# Altium schematic sheet 04_gap_interface.SchDoc(04_gap_interface)
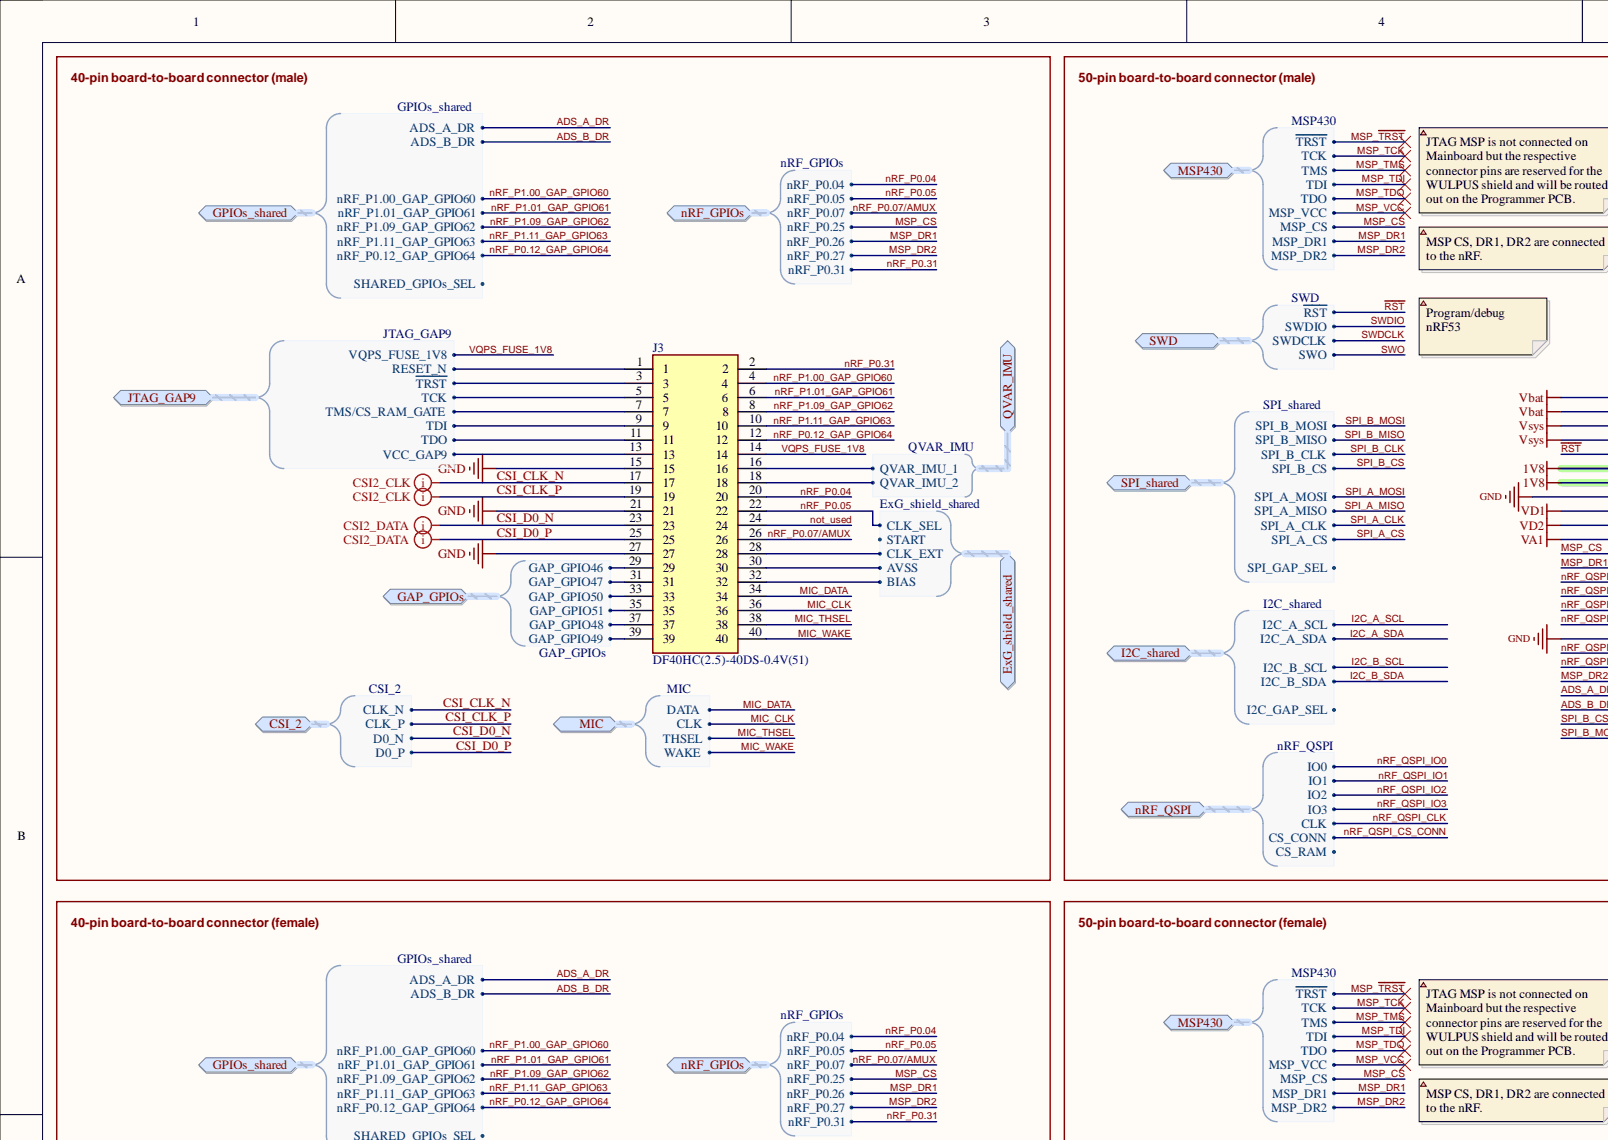
[diagram p0: 04_gap_interface.SchDoc, top left]
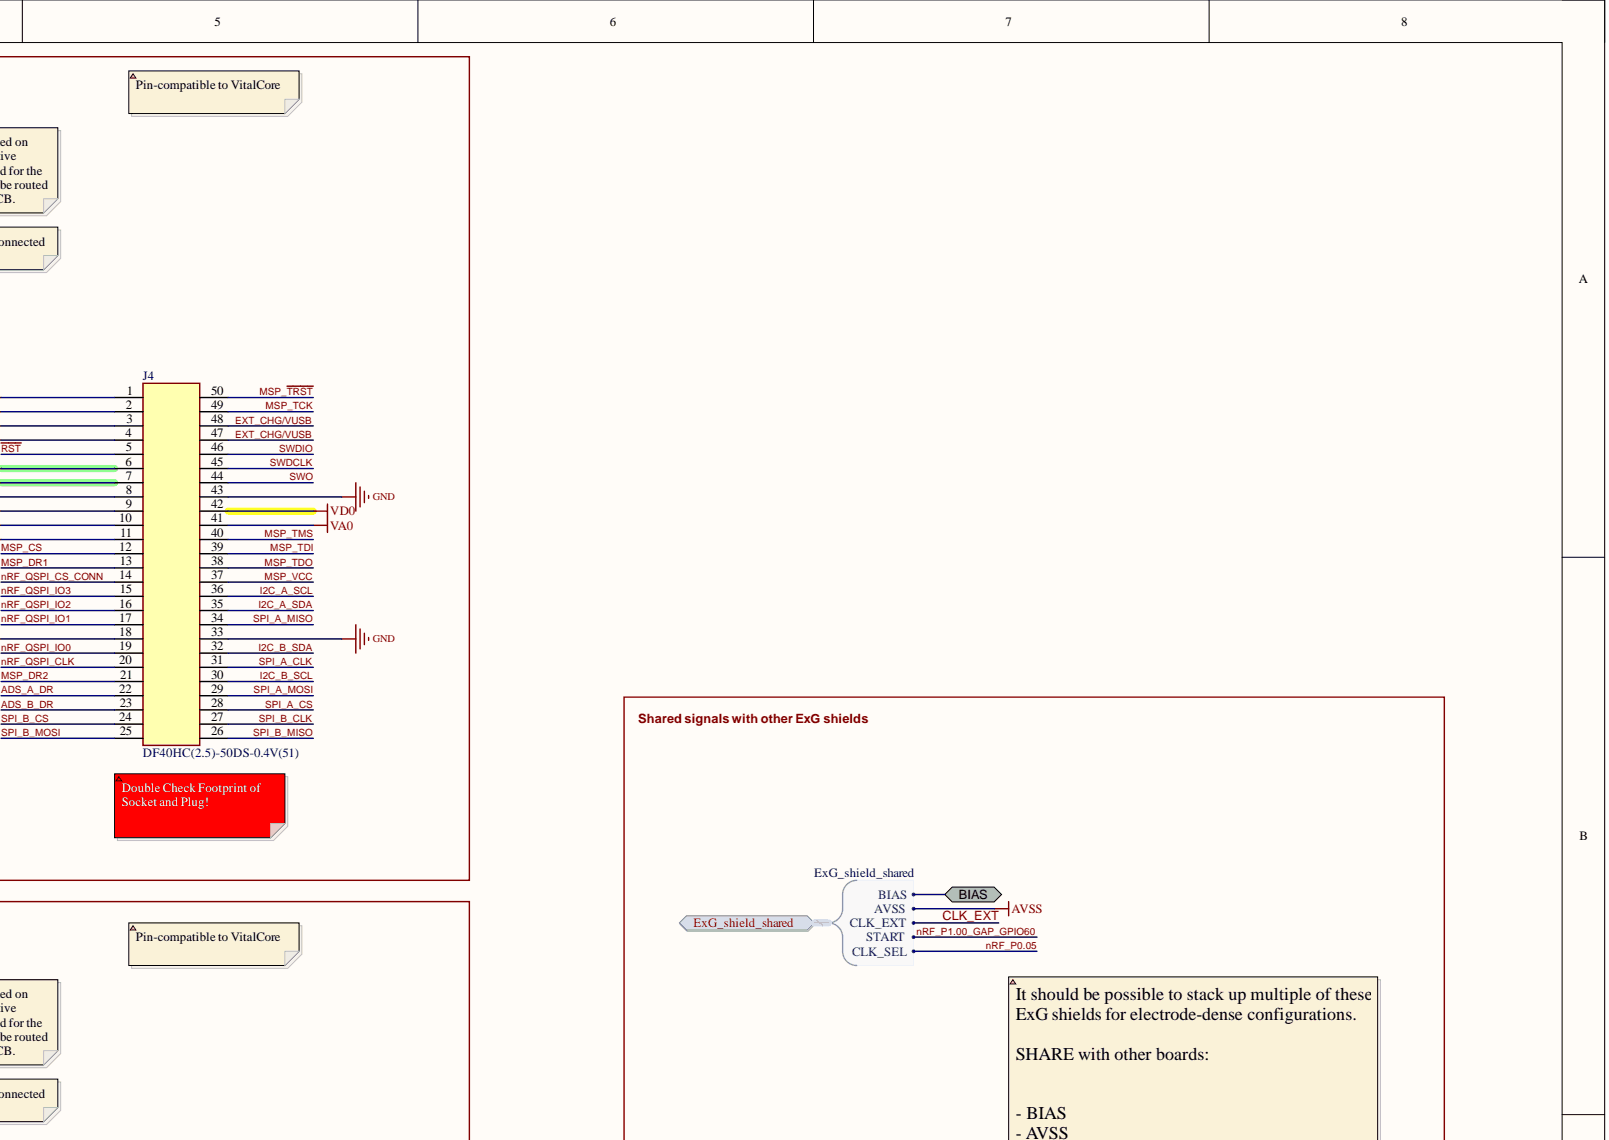
[diagram p1: 04_gap_interface.SchDoc, top right]
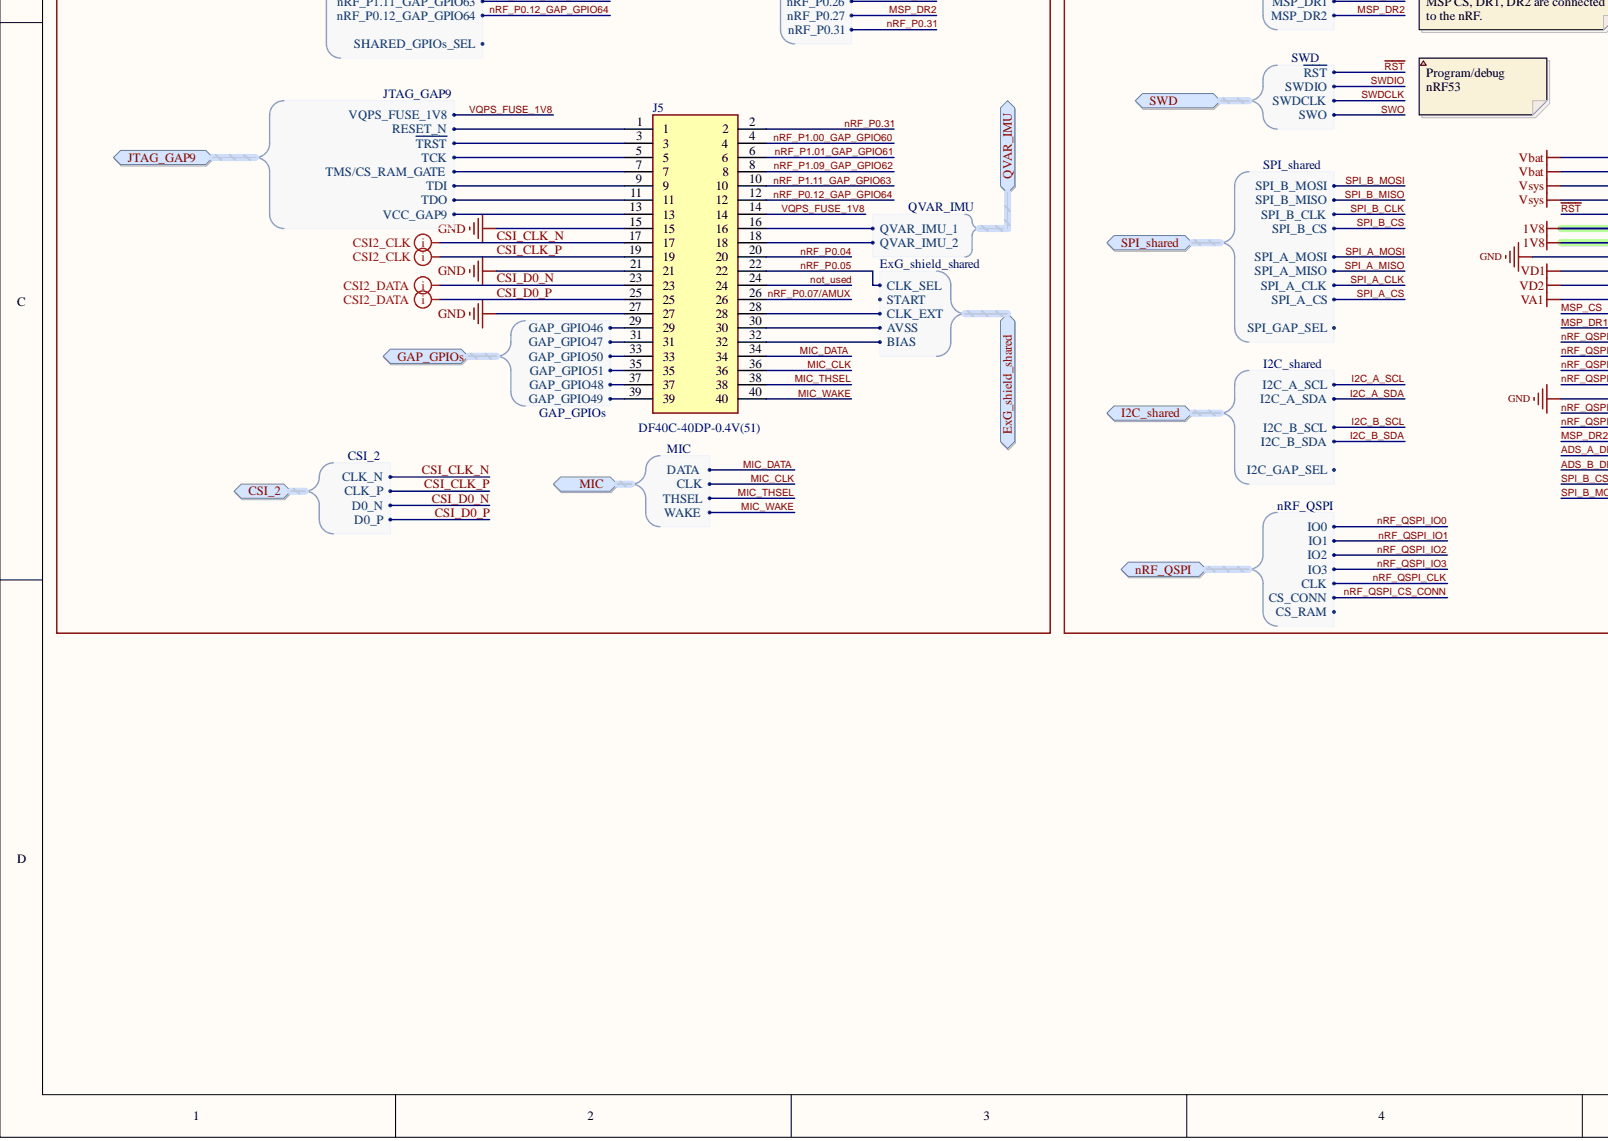
[diagram p2: 04_gap_interface.SchDoc, bottom left]
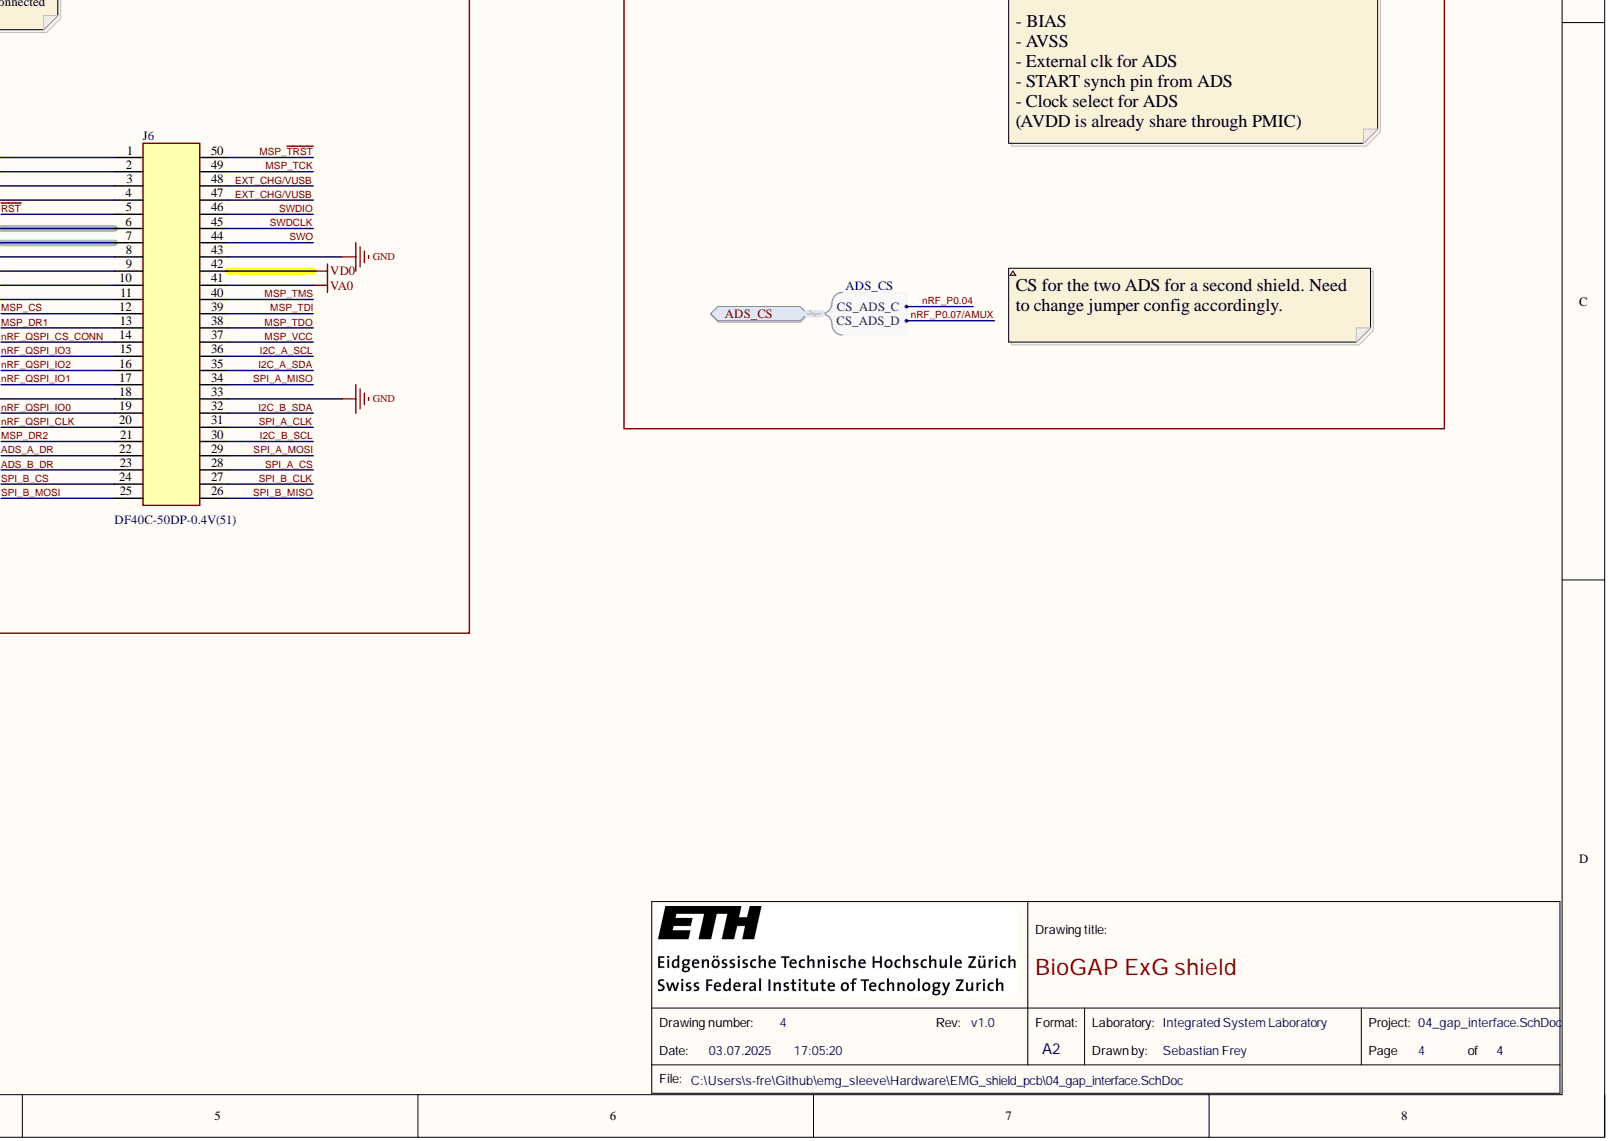
[diagram p3: 04_gap_interface.SchDoc, bottom right]

## Parts
J3  DF40HC(2.5)-40DS-0.4V(51)  [DF40HC3040DS04V51]
J4  DF40HC(2.5)-50DS-0.4V(51)  [DF40HC3050DS04V51]
J5  DF40C-40DP-0.4V(51)  [FP-DF40C-40DP-0_4V_51-MFG]
J6  DF40C-50DP-0.4V(51)  [CONN_COMBI_50P]

## Ports
ADS_CS, ADS_CS.CS_ADS_C, ADS_CS.CS_ADS_D, BIAS, CSI_2, CSI_2.CLK_N, CSI_2.CLK_P, CSI_2.D0_N, CSI_2.D0_P, ExG_shield_shared, ExG_shield_shared.AVSS, ExG_shield_shared.BIAS, ExG_shield_shared.CLK_EXT, ExG_shield_shared.CLK_SEL, ExG_shield_shared.START, GAP_GPIOs, GAP_GPIOs.GAP_GPIO46, GAP_GPIOs.GAP_GPIO47, GAP_GPIOs.GAP_GPIO48, GAP_GPIOs.GAP_GPIO49, GAP_GPIOs.GAP_GPIO50, GAP_GPIOs.GAP_GPIO51, GPIOs_shared, GPIOs_shared.ADS_A_DR, GPIOs_shared.ADS_B_DR, GPIOs_shared.nRF_P0.12_GAP_GPIO64, GPIOs_shared.nRF_P1.00_GAP_GPIO60, GPIOs_shared.nRF_P1.01_GAP_GPIO61, GPIOs_shared.nRF_P1.09_GAP_GPIO62, GPIOs_shared.nRF_P1.11_GAP_GPIO63, GPIOs_shared.SHARED_GPIOs_SEL, I2C_shared, I2C_shared.I2C_A_SCL, I2C_shared.I2C_A_SDA, I2C_shared.I2C_B_SCL, I2C_shared.I2C_B_SDA, I2C_shared.I2C_GAP_SEL, JTAG_GAP9, JTAG_GAP9.RESET_N, JTAG_GAP9.TCK, JTAG_GAP9.TDI, JTAG_GAP9.TDO, JTAG_GAP9.TMS/CS_RAM_GATE, JTAG_GAP9.VCC_GAP9, JTAG_GAP9.VQPS_FUSE_1V8, JTAG_GAP9.~{TRST}, MIC, MIC.CLK, MIC.DATA, MIC.THSEL, MIC.WAKE, MSP430, MSP430.MSP_CS, MSP430.MSP_DR1, MSP430.MSP_DR2, MSP430.MSP_VCC, MSP430.TCK, MSP430.TDI, MSP430.TDO, MSP430.TMS, MSP430.~{TRST}, nRF_GPIOs, nRF_GPIOs.nRF_P0.04, nRF_GPIOs.nRF_P0.05, nRF_GPIOs.nRF_P0.07, nRF_GPIOs.nRF_P0.25, nRF_GPIOs.nRF_P0.26, nRF_GPIOs.nRF_P0.27, nRF_GPIOs.nRF_P0.31, nRF_QSPI, nRF_QSPI.CLK, nRF_QSPI.CS_CONN, nRF_QSPI.CS_RAM, nRF_QSPI.IO0, nRF_QSPI.IO1, nRF_QSPI.IO2, nRF_QSPI.IO3, QVAR_IMU, QVAR_IMU.QVAR_IMU_1, QVAR_IMU.QVAR_IMU_2, SPI_shared, SPI_shared.SPI_A_CLK, SPI_shared.SPI_A_CS, SPI_shared.SPI_A_MISO, SPI_shared.SPI_A_MOSI, SPI_shared.SPI_B_CLK, SPI_shared.SPI_B_CS, SPI_shared.SPI_B_MISO, SPI_shared.SPI_B_MOSI, SPI_shared.SPI_GAP_SEL, SWD, SWD.SWDCLK, SWD.SWDIO, SWD.SWO, SWD.~{RST}

## Nets
1V8: J4.6 J4.7 J6.6 J6.7
GND: J3.15 J3.21 J3.27 J4.8 J4.18 J4.33 J4.43 J5.15 J5.21 J5.27 J6.8 J6.18 J6.33 J6.43
VA0: J4.41 J6.41
VA1: J4.11 J6.11
Vbat: J4.1 J4.2 J6.1 J6.2
VD0: J4.42 J6.42
VD1: J4.9 J6.9
VD2: J4.10 J6.10
Vsys: J4.3 J4.4 J6.3 J6.4
ADS_A_DR: J4.22 J6.22 ; port GPIOs_shared
ADS_B_DR: J4.23 J6.23 ; port GPIOs_shared
AVSS: J3.30 J5.30 ; port ExG_shield_shared
BIAS: J3.32 J5.32 ; port BIAS, port ExG_shield_shared
CLK_EXT: J3.28 J5.28 ; port ExG_shield_shared
CSI_CLK_N: J3.17 J5.17 ; port CSI_2
CSI_CLK_P: J3.19 J5.19 ; port CSI_2
CSI_D0_N: J3.23 J5.23 ; port CSI_2
CSI_D0_P: J3.25 J5.25 ; port CSI_2
EXT_CHG/VUSB: J4.47 J4.48 J6.47 J6.48
I2C_A_SCL: J4.36 J6.36 ; port I2C_shared
I2C_A_SDA: J4.35 J6.35 ; port I2C_shared
I2C_B_SCL: J4.30 J6.30 ; port I2C_shared
I2C_B_SDA: J4.32 J6.32 ; port I2C_shared
MIC_CLK: J3.36 J5.36 ; port MIC
MIC_DATA: J3.34 J5.34 ; port MIC
MIC_THSEL: J3.38 J5.38 ; port MIC
MIC_WAKE: J3.40 J5.40 ; port MIC
MSP_CS: J4.12 J6.12 ; port MSP430, port nRF_GPIOs
MSP_DR1: J4.13 J6.13 ; port MSP430, port nRF_GPIOs
MSP_DR2: J4.21 J6.21 ; port MSP430, port nRF_GPIOs
MSP_~{TRST}: J4.50 J6.50 ; port MSP430
MSP_TCK: J4.49 J6.49 ; port MSP430
MSP_TDI: J4.39 J6.39 ; port MSP430
MSP_TDO: J4.38 J6.38 ; port MSP430
MSP_TMS: J4.40 J6.40 ; port MSP430
MSP_VCC: J4.37 J6.37 ; port MSP430
not_used: J3.24 J5.24
nRF_P0.04: J3.20 J5.20 ; port ADS_CS, port nRF_GPIOs
nRF_P0.05: J3.22 J5.22 ; port ExG_shield_shared, port nRF_GPIOs
nRF_P0.07/AMUX: J3.26 J5.26 ; port ADS_CS, port nRF_GPIOs
nRF_P0.12_GAP_GPIO64: J3.12 J5.12 ; port GPIOs_shared
nRF_P0.31: J3.2 J5.2 ; port nRF_GPIOs
nRF_P1.00_GAP_GPIO60: J3.4 J5.4 ; port ExG_shield_shared, port GPIOs_shared
nRF_P1.01_GAP_GPIO61: J3.6 J5.6 ; port GPIOs_shared
nRF_P1.09_GAP_GPIO62: J3.8 J5.8 ; port GPIOs_shared
nRF_P1.11_GAP_GPIO63: J3.10 J5.10 ; port GPIOs_shared
nRF_QSPI_CLK: J4.20 J6.20 ; port nRF_QSPI
nRF_QSPI_CS_CONN: J4.14 J6.14 ; port nRF_QSPI
nRF_QSPI_IO0: J4.19 J6.19 ; port nRF_QSPI
nRF_QSPI_IO1: J4.17 J6.17 ; port nRF_QSPI
nRF_QSPI_IO2: J4.16 J6.16 ; port nRF_QSPI
nRF_QSPI_IO3: J4.15 J6.15 ; port nRF_QSPI
~{RST}: J4.5 J6.5 ; port SWD
SPI_A_CLK: J4.31 J6.31 ; port SPI_shared
SPI_A_CS: J4.28 J6.28 ; port SPI_shared
SPI_A_MISO: J4.34 J6.34 ; port SPI_shared
SPI_A_MOSI: J4.29 J6.29 ; port SPI_shared
SPI_B_CLK: J4.27 J6.27 ; port SPI_shared
SPI_B_CS: J4.24 J6.24 ; port SPI_shared
SPI_B_MISO: J4.26 J6.26 ; port SPI_shared
SPI_B_MOSI: J4.25 J6.25 ; port SPI_shared
SWDCLK: J4.45 J6.45 ; port SWD
SWDIO: J4.46 J6.46 ; port SWD
SWO: J4.44 J6.44 ; port SWD
VQPS_FUSE_1V8: J3.14 J5.14 ; port JTAG_GAP9
NetJ3_1: J3.1 J5.1 ; port JTAG_GAP9
NetJ3_3: J3.3 J5.3 ; port JTAG_GAP9
NetJ3_5: J3.5 J5.5 ; port JTAG_GAP9
NetJ3_7: J3.7 J5.7 ; port JTAG_GAP9
NetJ3_9: J3.9 J5.9 ; port JTAG_GAP9
NetJ3_11: J3.11 J5.11 ; port JTAG_GAP9
NetJ3_13: J3.13 J5.13 ; port JTAG_GAP9
NetJ3_16: J3.16 J5.16 ; port QVAR_IMU
NetJ3_18: J3.18 J5.18 ; port QVAR_IMU
NetJ3_29: J3.29 J5.29 ; port GAP_GPIOs
NetJ3_31: J3.31 J5.31 ; port GAP_GPIOs
NetJ3_33: J3.33 J5.33 ; port GAP_GPIOs
NetJ3_35: J3.35 J5.35 ; port GAP_GPIOs
NetJ3_37: J3.37 J5.37 ; port GAP_GPIOs
NetJ3_39: J3.39 J5.39 ; port GAP_GPIOs
N00016: ; port GPIOs_shared
N00023: ; port SPI_shared
N00024: ; port nRF_QSPI
N00027: ; port I2C_shared

## Library data of the parts
J3 = DF40HC(3.0)-40DS-0.4V(51): 40 Position 0.4mm Pitch Dual Row Vertical Surface Mount (SMT) Pin Socket, 2.5mm Stacking Height; System: Connector; Availability: Unavailable; Component Kind: Standard; Library Name: EEG_SchLib.SchLib; MP: DF40HC(3.0)-40DS-0.4V(51); Package: None; Price: None
J4 = DF40HC(3.0)-50DS-0.4V(51): 50 Position 0.4mm Pitch Dual Row Vertical Surface Mount (SMT) Pin Socket, 2.5mm Stacking Height; Mfr_Name: Hirose; Component Kind: Standard; Library Name: EEG_SchLib.SchLib; Manufacturer_Part_Number: DF40HC(3.0)-50DS-0.4V(51); RefDes: RefDes; Type: DEV; Copyright: Copyright (C) 2023 Ultra Librarian. All rights reserved.
J5 = DF40C-40DP-0.4V(51): 50 Position 0.4mm Pitch Dual Row Vertical Surface Mount (SMT) Receptacle; Connector Type: Plug; Current Rating: 300mA; Gender: Male; Mated Stacking Heights: 4mm; Number of Contacts: 40; System: Connector; Voltage Rating: 30V; Component Kind: Standard; Contact Material: Bronze; Contact Plating: Gold; Housing Material: Polymer; Lead Free: Lead Free; Library Name: EEG_SchLib.SchLib; Max Operating Temperature: 85°C; Min Operating Temperature: -35°C; Mount: Surface Mount; Number of Positions: 40; Number of Rows: 2; Orientation: Straight; Package Quantity: 1; Packaging: Tape and Reel; Pitch: 0.4mm; REACH SVHC: No SVHC; Termination: SMD/SMT
J6 = DF40C-50DP-0.4V(51): 50 Position 0.4mm Pitch Dual Row Vertical Surface Mount (SMT) Receptacle,; Mfr_Name: Hirose; System: Connector; Component Kind: Standard; Library Name: EEG_SchLib.SchLib; Manufacturer_Part_Number: DF40C-50DP-0.4V(51); RefDes: RefDes; Type: DEV; Copyright: Copyright (C) 2023 Ultra Librarian. All rights reserved.
